# Altium schematic sheet Mosfet.SchDoc
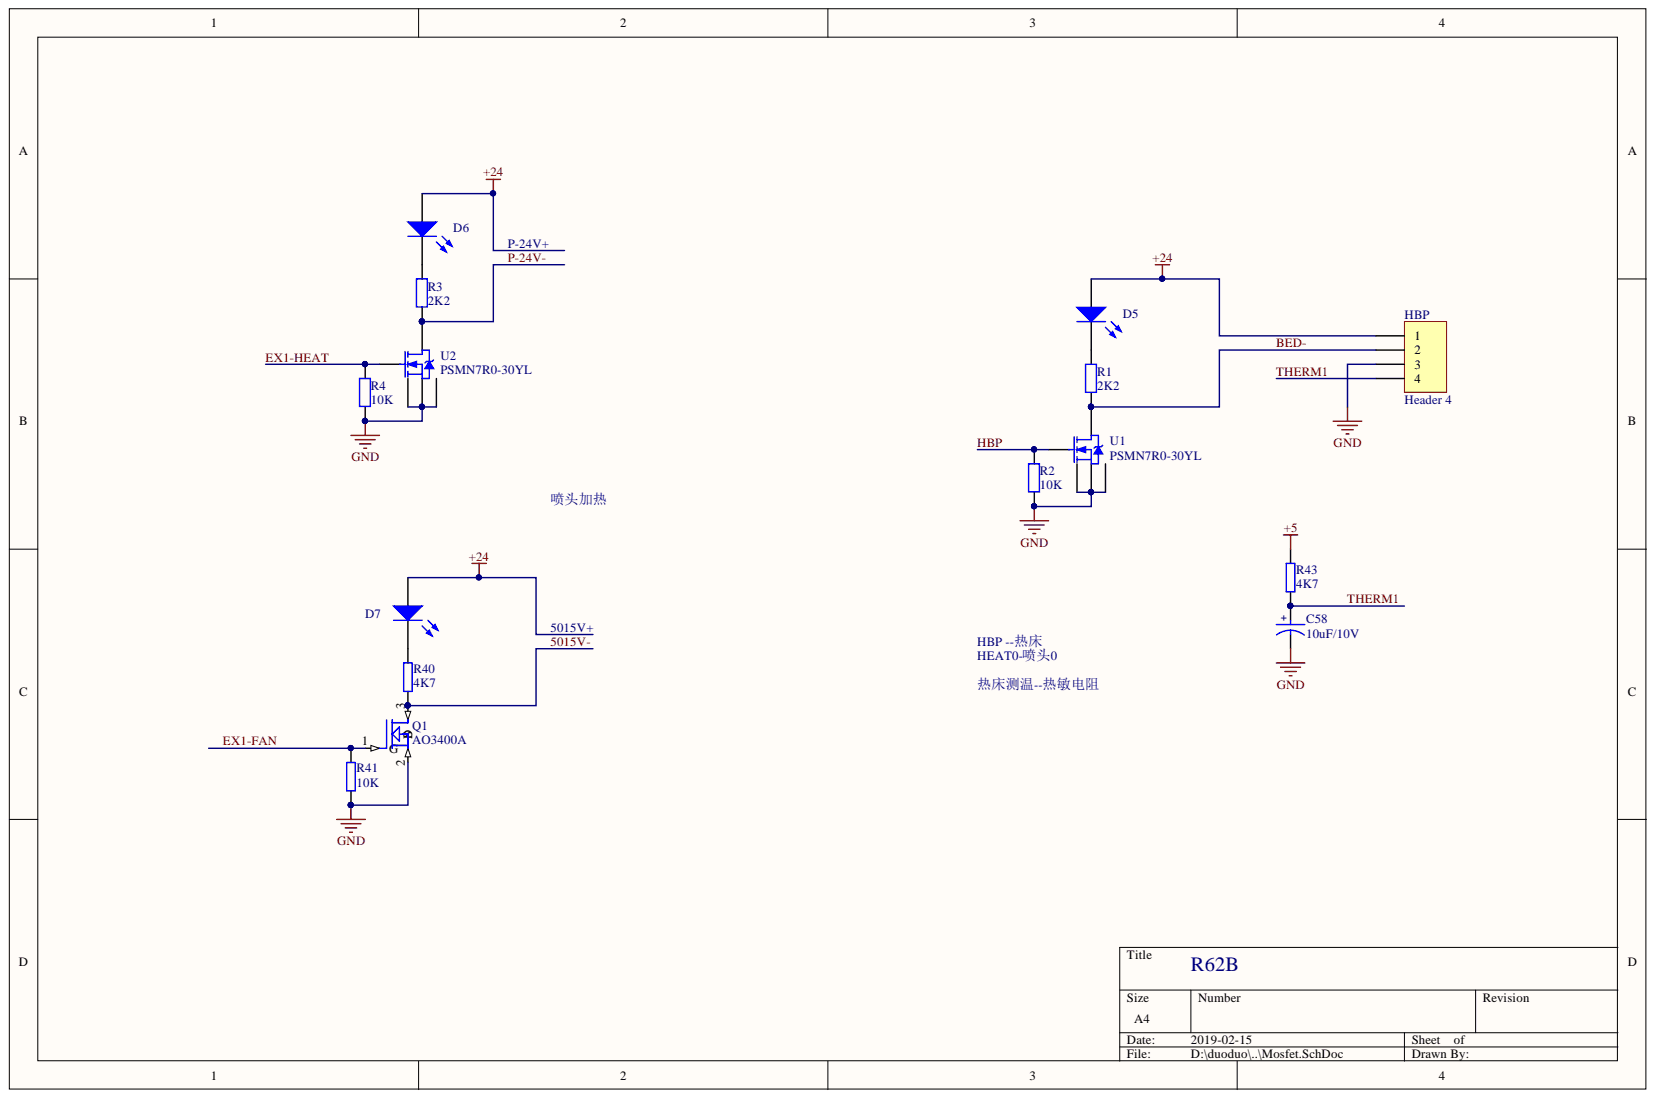
[diagram p0: Mosfet.SchDoc]

## Parts
C58  10uF/10V  [1206]
D5  LED3  [0603D]  (value not drawn)
D6  LED3  [0603D]  (value not drawn)
D7  LED3  [0603D]  (value not drawn)
HBP  Header 4  [VH3.96-4P]
Q1  AO3400A  [SOT-23-3L]
R1  2K2  [0603]
R2  10K  [0603]
R3  2K2  [0603]
R4  10K  [0603]
R40  4K7  [0603]
R41  10K  [0603]
R43  4K7  [0603]
U1  PSMN7R0-30YL  [SOT669]
U2  PSMN7R0-30YL  [SOT669]

## Nets
+5: R43.2
+24: D5.1 D6.1 D7.1 HBP.1
GND: C58.2 HBP.3 Q1.2 R2.2 R4.2 R41.2 U1.1 U1.2 U1.3 U2.1 U2.2 U2.3
5015V-: Q1.3 R40.1
BED-: HBP.2 R1.1 U1.5
EX1-FAN: Q1.1 R41.1
EX1-HEAT: R4.1 U2.4
HBP: R2.1 U1.4
P-24V-: R3.1 U2.5
THERM1: C58.1 HBP.4 R43.1
NetD5_2: D5.2 R1.2
NetD6_2: D6.2 R3.2
NetD7_2: D7.2 R40.2

## Library data of the parts
C58 = CAPACITOR POL: Component Kind: Standard; Description: Capacitor Pol; Library Name: DMSch.SCHLIB
D5-D7 = LED3: Typical BLUE SiC LED; Component Kind: Standard; DatasheetVersion: 16-Apr-2002; LatestRevisionDate: 17-Dec-2003; LatestRevisionNote: PCB Footprint replaced.; Library Name: Miscellaneous Devices.IntLib; PackageReference: 3.5X2.8X1.9; PackageVersion: 16-Apr-2002; Published: 8-Jun-2000; Signal Integrity: LED; Simulation: LED3; Publisher: Altium Limited
HBP = Header 4: Header, 4-Pin; Component Kind: Standard; LatestRevisionDate: 17-Jul-2002; LatestRevisionNote: Re-released for DXP Platform.; Library Name: Miscellaneous Connectors.IntLib; Signal Integrity: Connector; Publisher: Altium Limited
Q1 = MOSFET N: Component Kind: Standard; Library Name: Miscellaneous Devices.SCHLIB
R1-R4 = Res2: Resistor; Component Kind: Standard; Library Name: Miscellaneous Devices.IntLib; Signal Integrity: Res; Simulation: RESISTOR
R40 R41 R43 = RES5: Component Kind: Standard; Library Name: DMSch.SCHLIB
U1 U2 = N_MOSFET: N-Channel MOSFET; Component Kind: Standard; Library Name: DMSch.SCHLIB
